# Altium schematic sheet Sheet1.SchDoc(Sheet1)
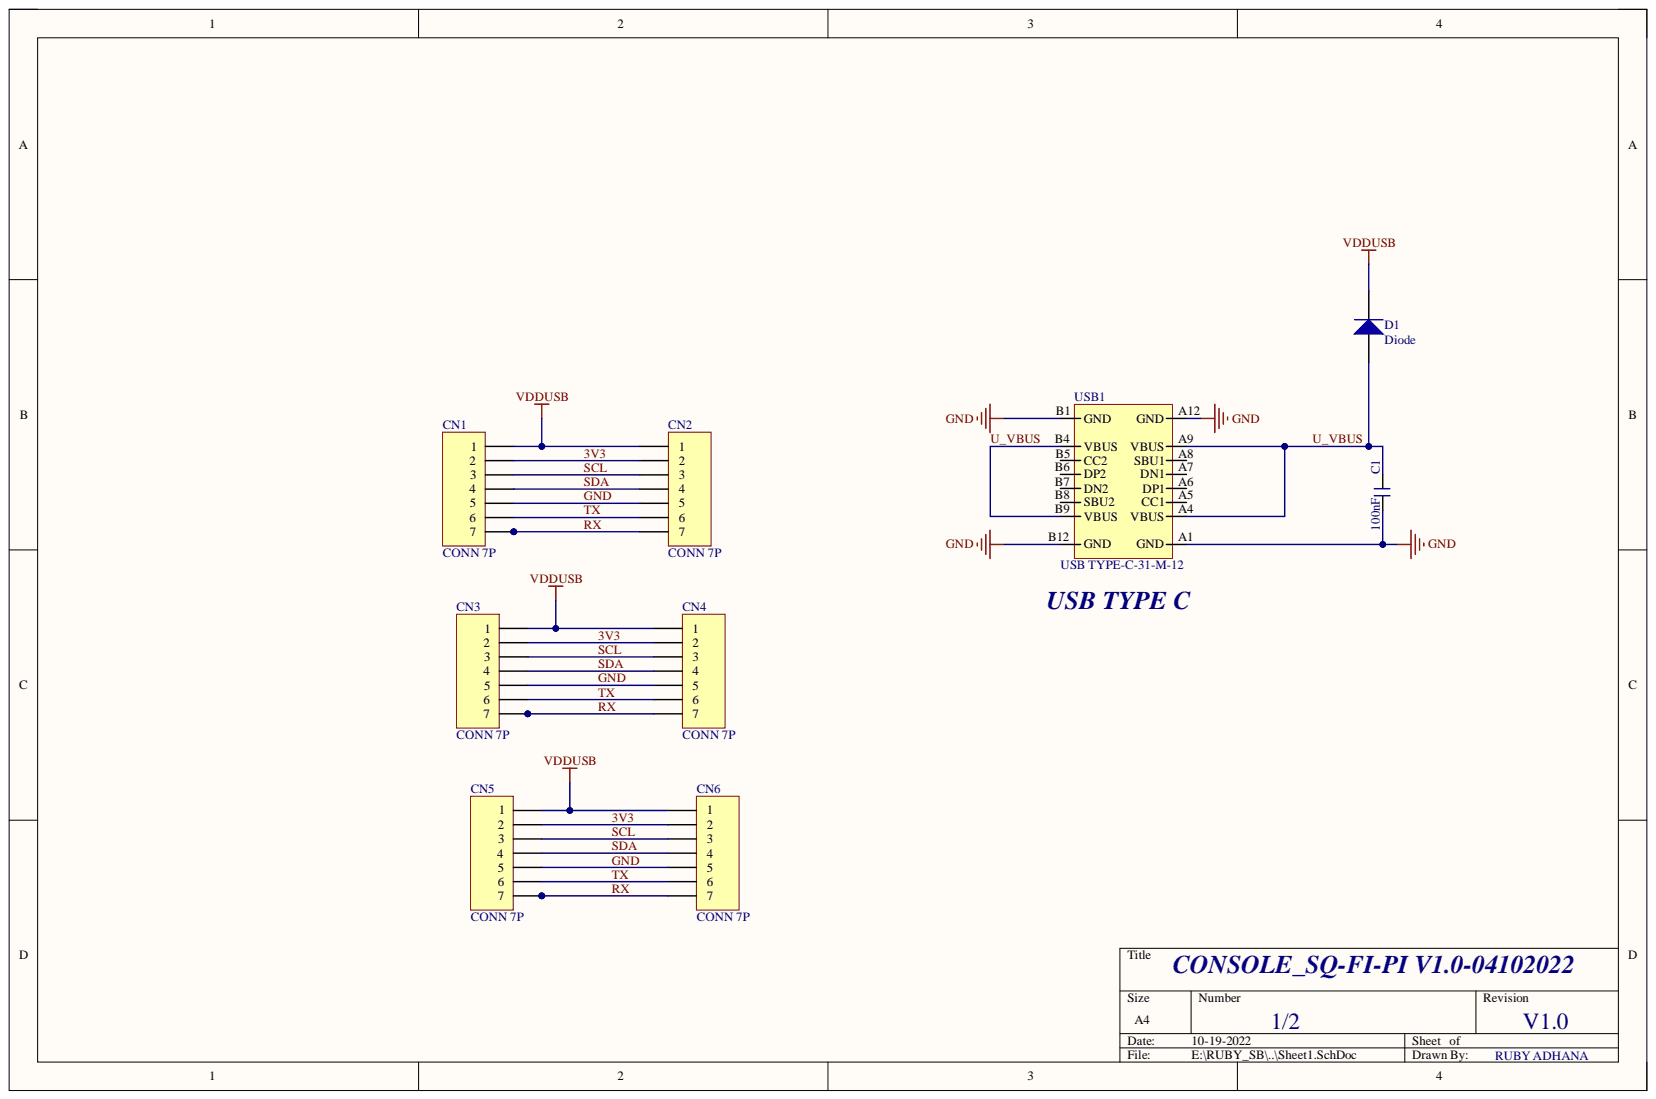
[diagram p0: Sheet1.SchDoc]

## Parts
C1  100nF  [C_0603]
CN1  CONN 7P  [7P BERG_2.54MM]
CN2  CONN 7P  [7P BERG_2.54MM]
CN3  CONN 7P  [7P BERG_2.54MM]
CN4  CONN 7P  [7P BERG_2.54MM]
CN5  CONN 7P  [7P BERG_2.54MM]
CN6  CONN 7P  [7P BERG_2.54MM]
D1  Diode  [SS32]
USB1  USB TYPE-C-31-M-12  [USBTYPE-C-31-M-12]

## Nets
VDDUSB: CN1.1 CN2.1 CN3.1 CN4.1 CN5.1 CN6.1 D1.2
3V3: CN1.2 CN2.2 CN3.2 CN4.2 CN5.2 CN6.2
GND: C1.2 CN1.5 CN2.5 CN3.5 CN4.5 CN5.5 CN6.5 USB1.A1(GND) USB1.A12(GND) USB1.B1(GND) USB1.B12(GND)
RX: CN1.7 CN2.7 CN3.7 CN4.7 CN5.7 CN6.7
SCL: CN1.3 CN2.3 CN3.3 CN4.3 CN5.3 CN6.3
SDA: CN1.4 CN2.4 CN3.4 CN4.4 CN5.4 CN6.4
TX: CN1.6 CN2.6 CN3.6 CN4.6 CN5.6 CN6.6
U_VBUS: C1.1 D1.1 USB1.A4(VBUS) USB1.A9(VBUS) USB1.B4(VBUS) USB1.B9(VBUS)

Not connected: USB1 A5(CC1) A6(DP1) A7(DN1) A8(SBU1) B5(CC2) B6(DP2) B7(DN2) B8(SBU2)

## Library data of the parts
C1 = CAP_NONPOLAR: Component Kind: Standard; Library Name: dxp_pasl_lib.IntLib
CN1-CN6 = Header 7: Header, 7-Pin; Component Kind: Standard; LatestRevisionDate: 17-Jul-2002; LatestRevisionNote: Re-released for DXP Platform.; Library Name: Miscellaneous Connectors.IntLib; Signal Integrity: Connector; Publisher: Altium Limited
D1 = Diode: Default Diode; Code_JEDEC: DO-214-AB; Component Kind: Standard; LatestRevisionDate: 17-Jul-2002; LatestRevisionNote: Re-released for DXP Platform.; Library Name: Miscellaneous Devices.IntLib; PackageDescription: DO-214-AB/SMC; 2 C-Bend Leads; Body 7.9 x 5.9 mm, inc. leads (LxW); PackageReference: SMC; PackageVersion: Sep-1996; Published: 8-Jun-2000; Signal Integrity: Diode; Simulation: DIODE; Publisher: Altium Limited
USB1 = USB TYPE-C KH-TYPE-C-16P: 3A 1 Surface Mount 16 Female -40?~+85? Type-C SMD USB Connectors ROHS #  C709357; Component Kind: Standard; ComponentLink2Description: New link; ComponentLink2URL: https://lcsc.com/product-detail/USB-Connectors_Shenzhen-Kinghelm-Elec-KH-TYPE-C-16P_C709357.html; Supplier 1: LCSC; Supplier Part Number 1: C165948; Library Name: dxp_pasl_lib.IntLib
